# Altium schematic sheet CAN Devices.SchDoc
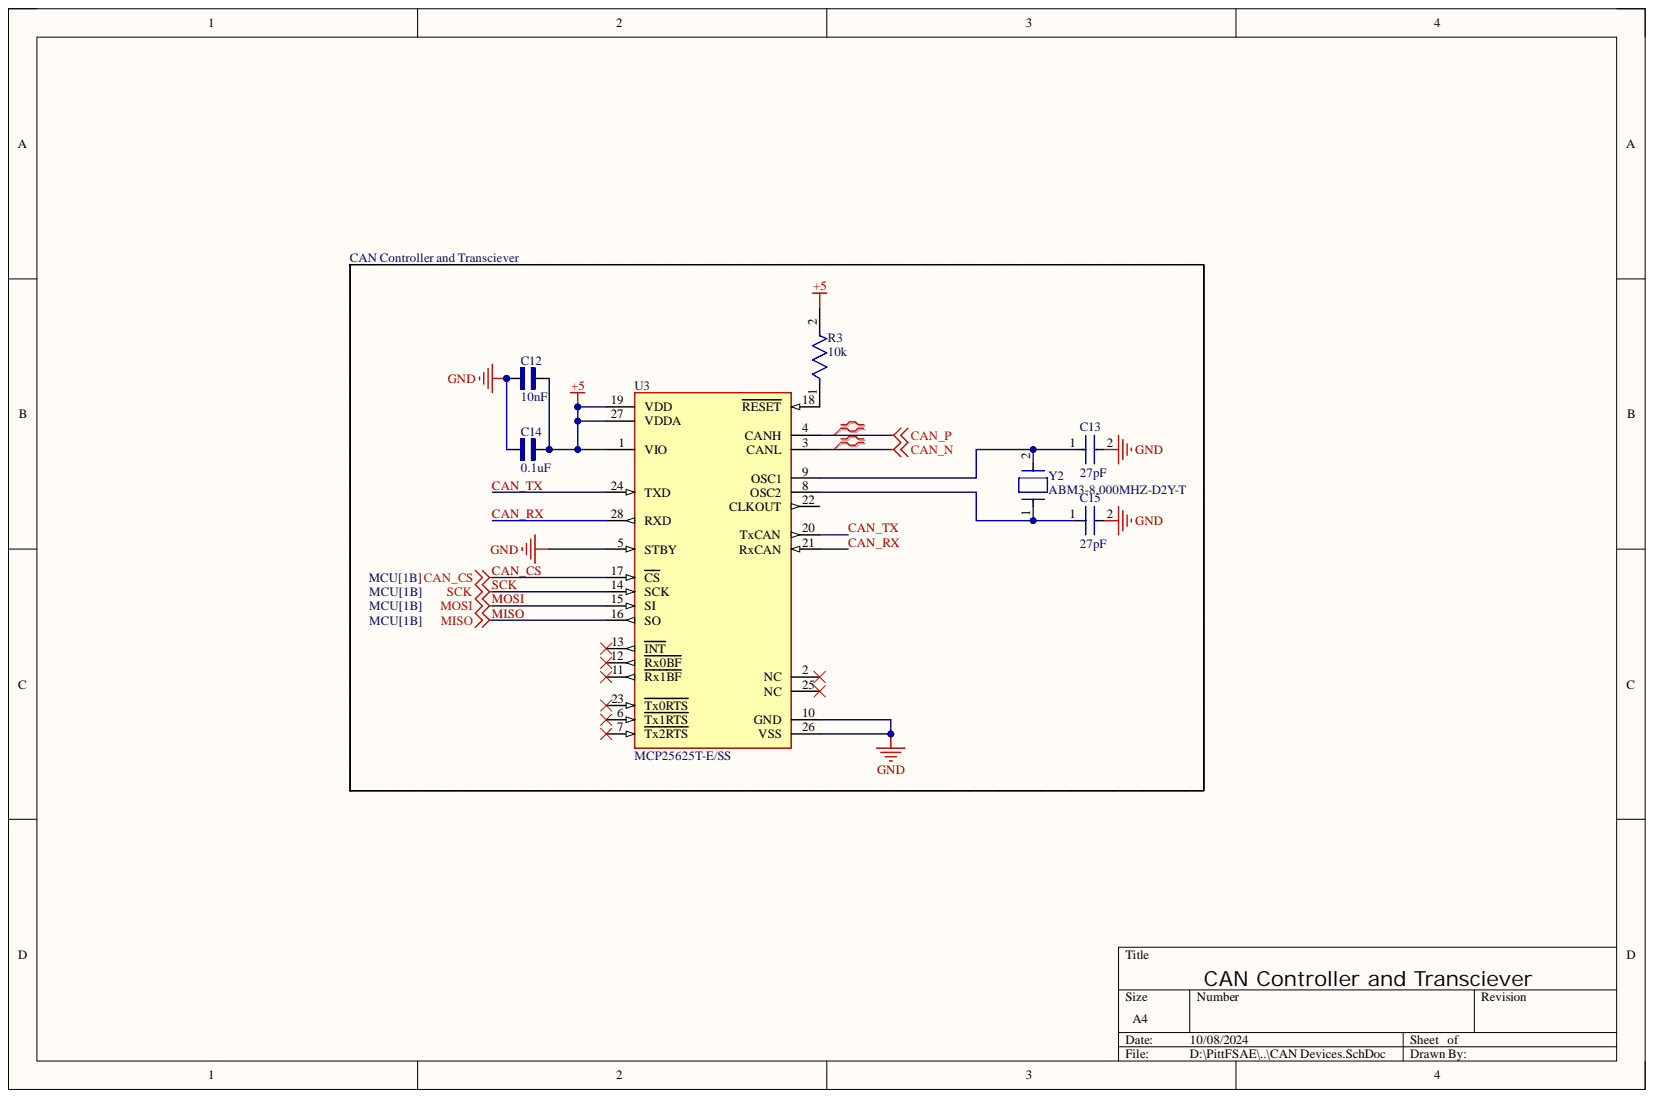
[diagram p0: CAN Devices.SchDoc]

## Parts
C12  10nF  [CAPC2012X88N]
C13  27pF  [FP-0805-L_2_01_0_2-W_1_25-MFG]
C14  0.1uF  [CAPC2012X135N]
C15  27pF  [FP-0805-L_2_01_0_2-W_1_25-MFG]
R3  10k  [FP-CRCW0805-e3-IPC_A]
U3  MCP25625T-E/SS  [FP-C04-073-IPC_B]
Y2  ABM3-8.000MHZ-D2Y-T  [FP-ABM3-MFG]

## Nets
+5: C12.1 C14.1 R3.2 U3.1(VIO) U3.19(VDD) U3.27(VDDA)
CAN_N: U3.3(CANL)
CAN_P: U3.4(CANH)
GND: C12.2 C13.2 C14.2 C15.2 U3.5(STBY) U3.10(GND) U3.26(VSS)
CAN_CS: U3.17(CS)
CAN_RX: U3.21(RxCAN) U3.28(RXD)
CAN_TX: U3.20(TxCAN) U3.24(TXD)
MISO: U3.16(SO)
MOSI: U3.15(SI)
SCK: U3.14(SCK)
NetC13_1: C13.1 U3.9(OSC1) Y2.2
NetC15_1: C15.1 U3.8(OSC2) Y2.1
NetR3_1: R3.1 U3.18(RESET)

Not connected: U3 2(NC) 6(Tx1RTS) 7(Tx2RTS) 11(Rx1BF) 12(Rx0BF) 13(INT) 22(CLKOUT) 23(Tx0RTS) 25(NC)

## Library data of the parts
C12 = C0805C103F5GACTU: CAP CER 10000PF 50V C0G/NP0 0805; MF: {'manufacturer': u'KEMET'}; Availability: Unavailable; Component Kind: Standard; MP: C0805C103F5GACTU; Package: None; Price: None
C13 C15 = CMP-2007-04505-2: General Purpose Ceramic Capacitor, 0805, 27pF, 5%, C0G, 30ppm/°C, 50V; Capacitance: 27pF; Case Code (Imperial): 0805; Case Code (Metric): 2012; Case/Package: 0805; Component Kind: Standard; Construction: Flat; Contact Plating: Tin; Depth: 1.25mm; Dielectric: C0G; Dielectric Material: Ceramic; Height: 0.71mm; Lead Free: Lead Free; Length: 2.01mm; Library Name: Altium Content Vault; Max Operating Temperature: 125°C; Min Operating Temperature: -55°C; Mount: Surface Mount; Number of Pins: 2; Package Quantity: 1; Packaging: Tape and Reel; Radiation Hardening: No; REACH SVHC: No SVHC; RoHS Compliant: Yes; Series: -; Termination: SMD/SMT; Thickness: 0.037inch; Tolerance: 5%; Voltage: 50V; Voltage Rating: 50V; Voltage Rating (DC): 50V; Width: 0.049inch
C14 = GCM21BR71H104KA37L: CAP, MLCC, X7R, 0.1UF, 50V, 0805; MF: {'manufacturer': u'Murata'}; Availability: Unavailable; Component Kind: Standard; MP: GCM21BR71H104KA37L; Package: None; Price: None
R3 = CMP-2001-04908-2: RES Thick Film, 10kΩ, 1%, 0.125W, 100ppm/°C, 0805; Power Rating: 125mW; Resistance: 10kR; Voltage Rating: 150V; Case Code (Imperial): 0805; Case Code (Metric): 2012; Case/Package: 0805; Component Kind: Standard; Composition: Thick Film; Contact Plating: Tin; Depth: 1.25mm; Height: 0.45mm; Lead Free: Lead Free; Length: 2mm; Library Name: Altium Content Vault; Max Operating Temperature: 155°C; Military Standard: Not; Min Operating Temperature: -55°C; Package Quantity: 5000; Packaging: Tape and Reel; Radiation Hardening: No; Temperature Coefficient: 100ppm/°C; Termination: SMD/SMT; Tolerance: 1%; Voltage Rating (DC): 150V; Weight: 0.000194oz; Width: 1.25mm
U3 = CMP-06279-000016-1: IC TRANSCEIVER 1/1 28SSOP; Data Rate: 1Mbps; Interface: SPI; Max Supply Voltage: 5.5V; Min Supply Voltage: 4.5V; Number of Drivers: 1; Operating Supply Current: 70mA; Propagation Delay: 125ns; Receiver Hysteresis: 200mV; Case/Package: SSOP; Component Kind: Standard; Library Name: Altium Content Vault; Max Operating Temperature: 125°C; Min Operating Temperature: -40°C; Mount: Surface Mount; Number of Pins: 28; Number of Receivers: 1; Number of Transceivers: 1; Package Quantity: 2100; Packaging: Tape and Reel; RoHS Compliant: Yes; Weight: 0.080001oz
Y2 = CMP-2000-05033-2: Crystal 8MHz ±20ppm 18pF SMD-2 5mm x 3.2mm; ESR (Equivalent Series Resistance): 140R; Frequency Stability: 30ppm; Series Resistance: 500R; Case/Package: CSMD; Component Kind: Standard; Depth: 3.2mm; Frequency: 8MHz; Frequency Tolerance: 20ppm; Height: 0.051inch; Lead Free: Lead Free; Length: 5mm; Library Name: Altium Content Vault; Load Capacitance: 18pF; Max Operating Temperature: 85°C; Min Operating Temperature: -40°C; Mount: Surface Mount; Number of Pins: 2; Package Quantity: 1000; Packaging: Tape and Reel; Radiation Hardening: No; Resistor Type: Ceramic; RoHS Compliant: Yes; Termination: SMD/SMT; Tolerance: 20ppm; Width: 3.2mm
